# Altium schematic sheet CH559.SchDoc(CH559)
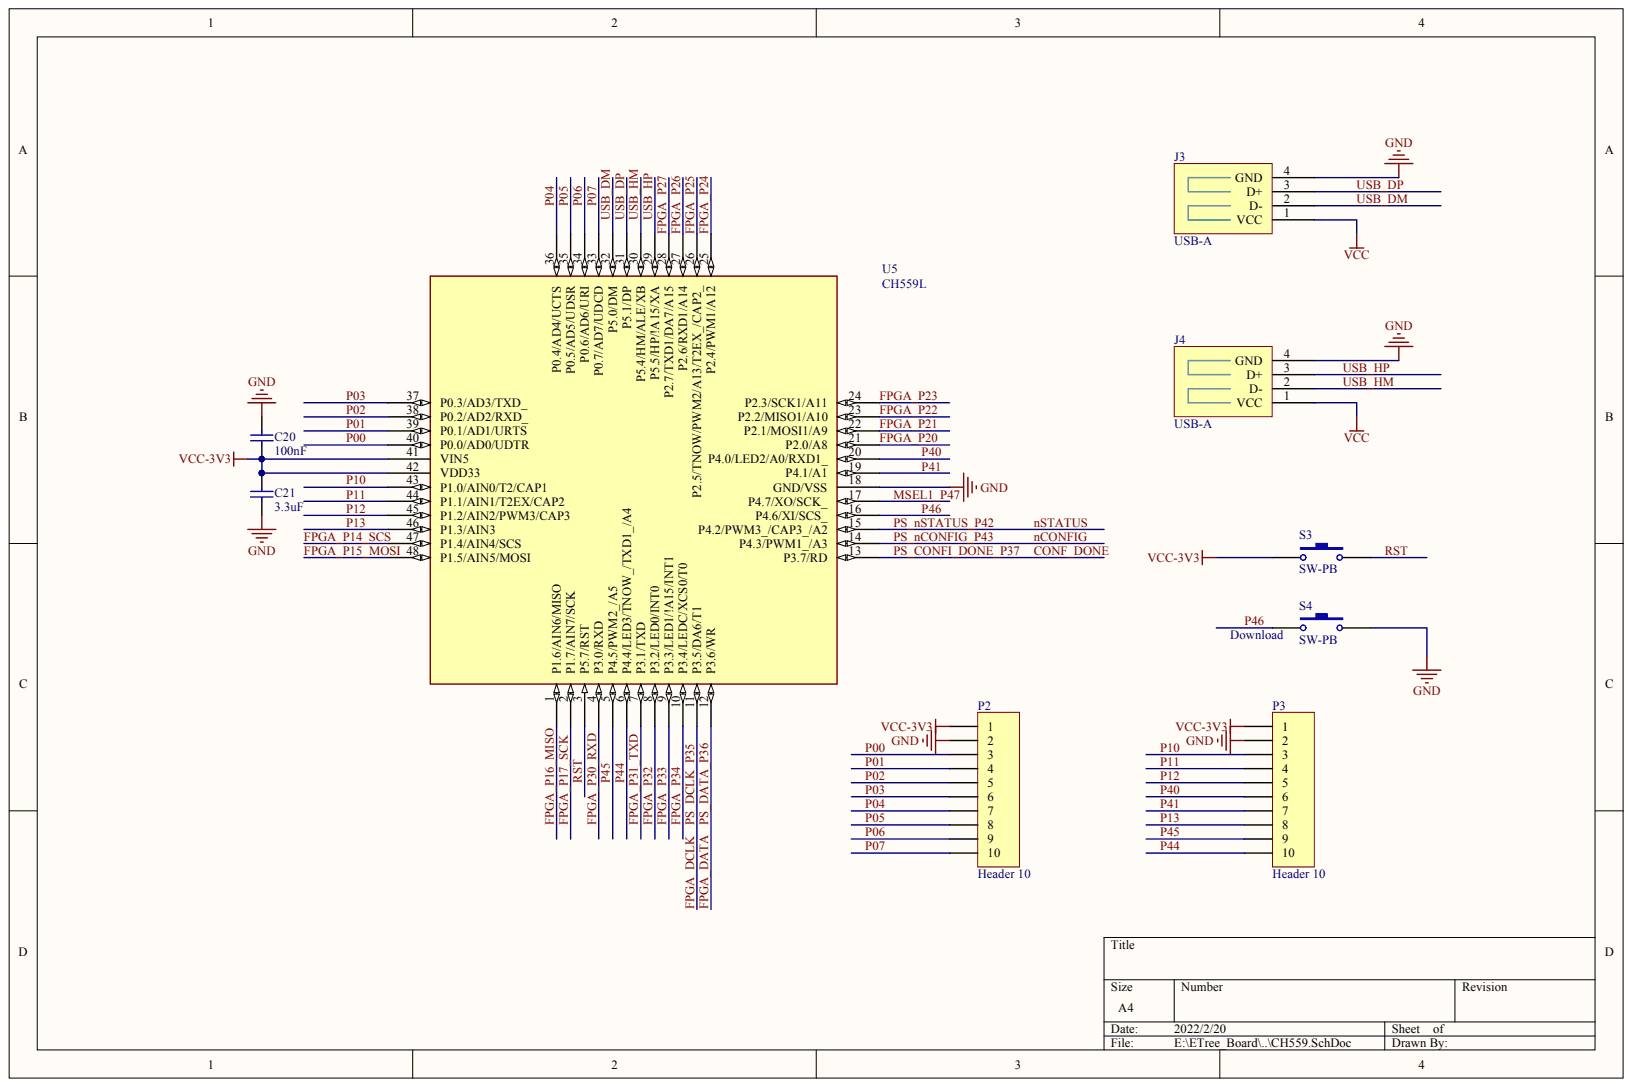
[diagram p0: CH559.SchDoc]

## Parts
C20  value 100nF  (hidden comment: Cap)
C21  value 3.3uF  (hidden comment: Cap)
J3  USB-A
J4  USB-A
P2  Header 10
P3  Header 10
S3  SW-PB
S4  SW-PB
U5  CH559L

## Nets
GND: C20.2 C21.1 J3.4(GND) J4.4(GND) P2.2 P3.2 S4.2 U5.18(GND/VSS)
VCC: J3.1(VCC) J4.1(VCC)
VCC-3V3: C20.1 C21.2 P2.1 P3.1 S3.1 U5.41(VIN5) U5.42(VDD33)
CONF_DONE: U5.13(P3.7/RD)
FPGA_DATA: U5.12(P3.6/WR)
FPGA_DCLK: U5.11(P3.5/DA6/T1)
FPGA_P14_SCS: U5.47(P1.4/AIN4/SCS)
FPGA_P15_MOSI: U5.48(P1.5/AIN5/MOSI)
FPGA_P16_MISO: U5.1(P1.6/AIN6/MISO)
FPGA_P17_SCK: U5.2(P1.7/AIN7/SCK)
FPGA_P20: U5.21(P2.0/A8)
FPGA_P21: U5.22(P2.1/MOSI1/A9)
FPGA_P22: U5.23(P2.2/MISO1/A10)
FPGA_P23: U5.24(P2.3/SCK1/A11)
FPGA_P24: U5.25(P2.4/PWM1/A12)
FPGA_P25: U5.26(P2.5/TNOW/PWM2/A13/T2EX_/CAP2_)
FPGA_P26: U5.27(P2.6/RXD1/A14)
FPGA_P27: U5.28(P2.7/TXD1/DA7/A15)
FPGA_P30_RXD: U5.4(P3.0/RXD)
FPGA_P31_TXD: U5.7(P3.1/TXD)
FPGA_P32: U5.8(P3.2/LED0/INT0)
FPGA_P33: U5.9(P3.3/LED1/!A15/INT1)
FPGA_P34: U5.10(P3.4/LEDC/XCS0/T0)
MSEL1_P47: U5.17(P4.7/XO/SCK_)
NCONFIG: U5.14(P4.3/PWM1_/A3)
NSTATUS: U5.15(P4.2/PWM3_/CAP3_/A2)
P00: P2.3 U5.40(P0.0/AD0/UDTR)
P01: P2.4 U5.39(P0.1/AD1/URTS)
P02: P2.5 U5.38(P0.2/AD2/RXD_)
P03: P2.6 U5.37(P0.3/AD3/TXD_)
P04: P2.7 U5.36(P0.4/AD4/UCTS)
P05: P2.8 U5.35(P0.5/AD5/UDSR)
P06: P2.9 U5.34(P0.6/AD6/URI)
P07: P2.10 U5.33(P0.7/AD7/UDCD)
P10: P3.3 U5.43(P1.0/AIN0/T2/CAP1)
P11: P3.4 U5.44(P1.1/AIN1/T2EX/CAP2)
P12: P3.5 U5.45(P1.2/AIN2/PWM3/CAP3)
P13: P3.8 U5.46(P1.3/AIN3)
P40: P3.6 U5.20(P4.0/LED2/A0/RXD1_)
P41: P3.7 U5.19(P4.1/A1)
P44: P3.10 U5.6(P4.4/LED3/TNOW_/TXD1_/A4)
P45: P3.9 U5.5(P4.5/PWM2_/A5)
P46: S4.1 U5.16(P4.6/XI/SCS_)
RST: S3.2 U5.3(P5.7/RST)
USB_DM: J3.2(D-) U5.32(P5.0/DM)
USB_DP: J3.3(D+) U5.31(P5.1/DP)
USB_HM: J4.2(D-) U5.30(P5.4/HM/ALE/XB)
USB_HP: J4.3(D+) U5.29(P5.5/HP/!A15/XA)

## Library data of the parts
C20 C21 J3 J4 P2 P3 S3 S4 U5 = (no symbol name)
  C20 C21: Published: 8-Jun-2000; LatestRevisionDate: 17-Jul-2002; LatestRevisionNote: Re-released for DXP Platform.; PackageReference: RAD-0.3; PackageDescription: Radial Cap, Thru-Hole; 2 Leads; 0.3 in Pin Spacing
  P2 P3: LatestRevisionDate: 17-Jul-2002; LatestRevisionNote: Re-released for DXP Platform.
  S3 S4: Published: 24-Mar-1999; LatestRevisionDate: 17-Jul-2002; LatestRevisionNote: Re-released for DXP Platform.; PackageReference: SPST-2; PackageDescription: Switch; 2 Leads
  C20 C21 P2 P3 S3 S4: Publisher: Altium Limited
